# Altium schematic sheet 01-Antennas.SchDoc(01-Antennas)
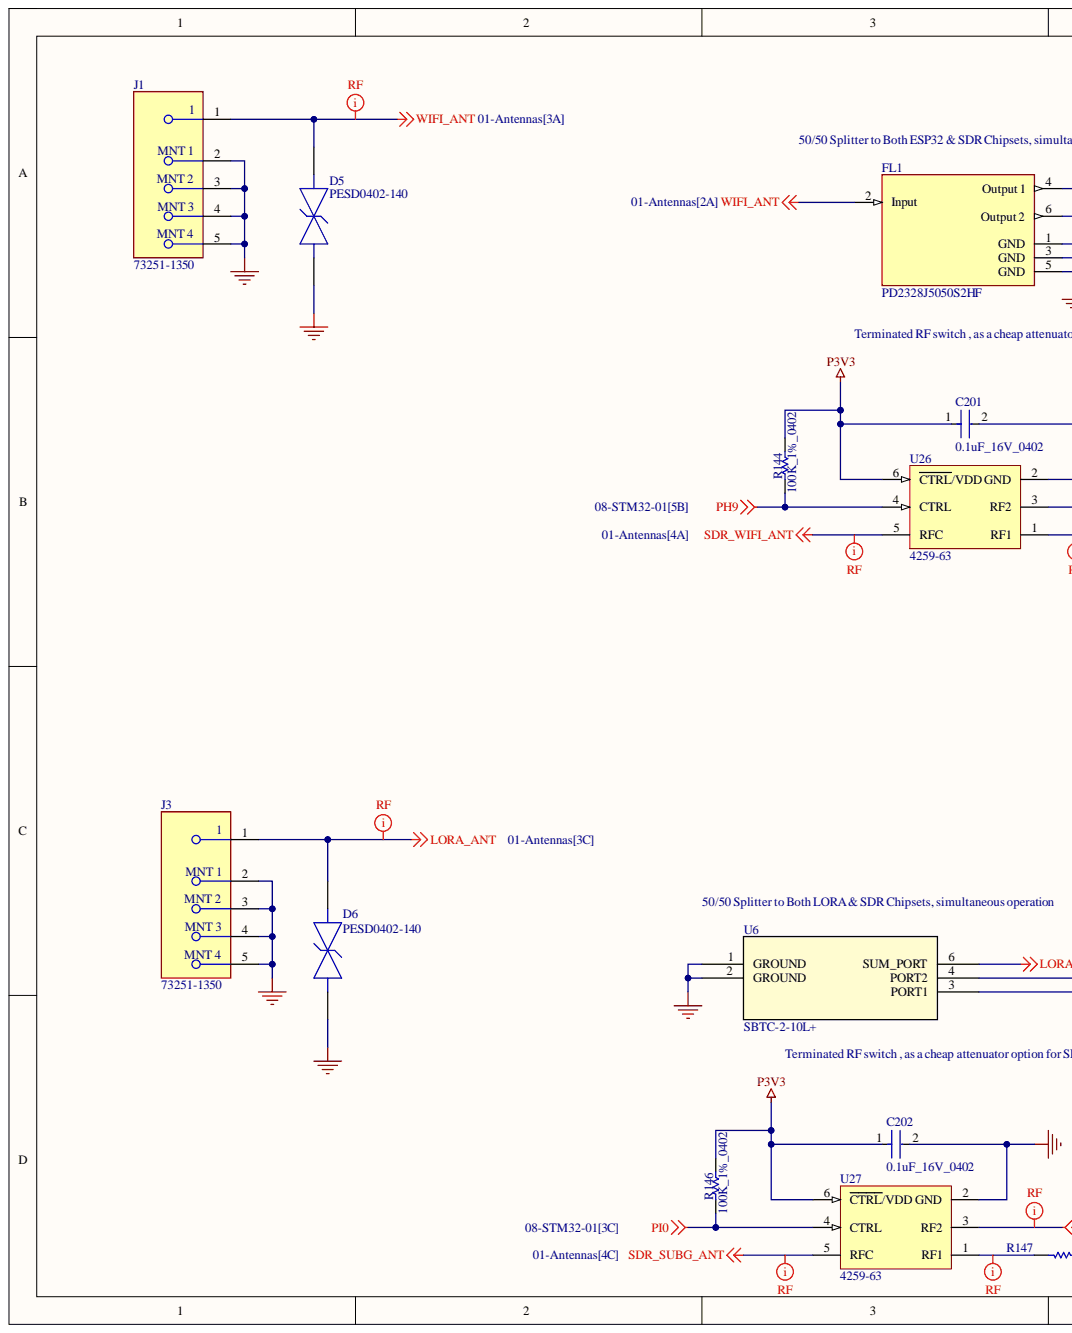
[diagram p0: 01-Antennas.SchDoc, left]
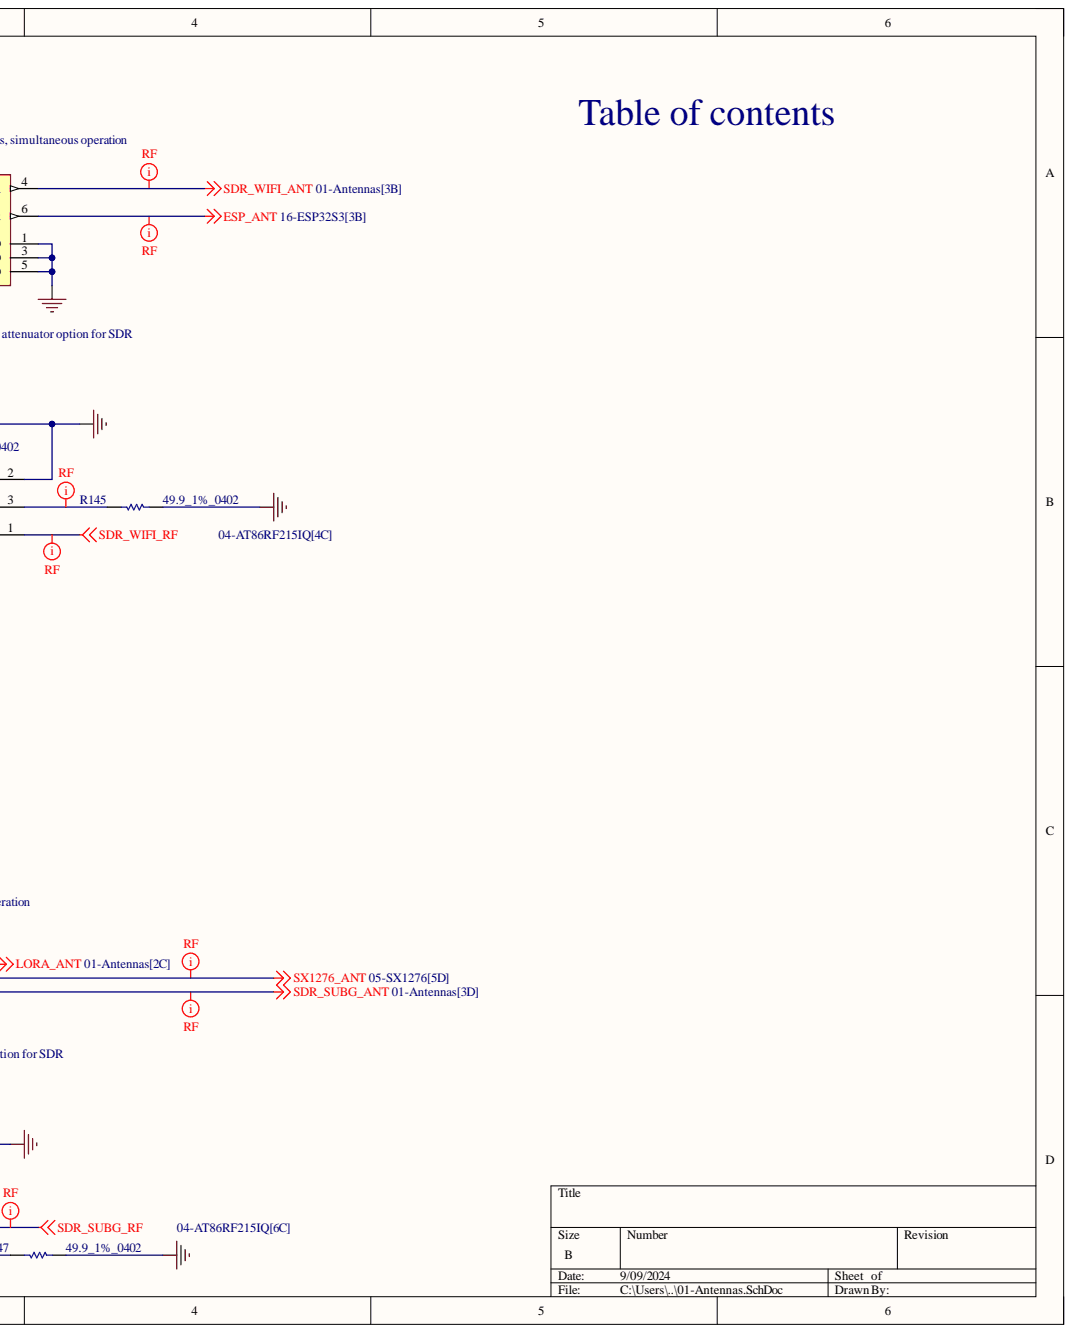
[diagram p1: 01-Antennas.SchDoc, right]

## Parts
C201  0.1uF_16V_0402  [FP-C0402-BB-MFG]
C202  0.1uF_16V_0402  [FP-C0402-BB-MFG]
D5  PESD0402-140  [FP-PESD0402-MFG]
D6  PESD0402-140  [FP-PESD0402-MFG]
FL1  PD2328J5050S2HF  [FP-PD2328J5050S2HF-MFG]
J1  73251-1350  [FP-73251-1350-MFG]
J3  73251-1350  [FP-73251-1350-MFG]
R144  100K_1%_0402  [RESC1005X40X25ML05T10]
R145  49.9_1%_0402  [RESC1005X40X25NL10T10]
R146  100K_1%_0402  [RESC1005X40X25ML05T10]
R147  49.9_1%_0402  [RESC1005X40X25NL10T10]
U6  SBTC-2-10L+  [AT1029_MNC]
U26  4259-63  [PERE-SC-70-6_M]
U27  4259-63  [PERE-SC-70-6_M]

## Nets
ESP_ANT: FL1.6(Output_2)
GND: C201.2 C202.2 D5.1 D6.1 FL1.1(GND) FL1.3(GND) FL1.5(GND) J1.2 J1.3 J1.4 J1.5 J3.2 J3.3 J3.4 J3.5 R145.2 R147.2 U6.1(GROUND) U6.2(GROUND) U26.2(GND) U27.2(GND)
LORA_ANT: D6.2 J3.1 U6.6(SUM_PORT)
P3V3: C201.1 C202.1 R144.2 R146.2 U26.6(CTRL/VDD) U27.6(CTRL/VDD)
PH9: R144.1 U26.4(CTRL)
PI0: R146.1 U27.4(CTRL)
SDR_SUBG_ANT: U6.3(PORT1) U27.5(RFC)
SDR_SUBG_RF: U27.3(RF2)
SDR_WIFI_ANT: FL1.4(Output_1) U26.5(RFC)
SDR_WIFI_RF: U26.1(RF1)
SX1276_ANT: U6.4(PORT2)
WIFI_ANT: D5.2 FL1.2(Input) J1.1
NetR145_1: R145.1 U26.3(RF2)
NetR147_1: R147.1 U27.1(RF1)

## Library data of the parts
C201 C202 = CMP-1034-02068-3: CAP CER 0.1UF 16V 5% X7R 0402; Manufacturer: Kemet; Manufacturer Part Number: C0402C104J4RACTU; Capacitance: 0.10 μF; Material: Ceramic; Temperature Coefficient: 15%; Tolerance: 0.05; Value: 0.10 μF; Automotive: No; Category: Cap; Component Kind: Standard; ComponentLink2Description: Datasheet; ComponentLink2URL: https://content.kemet.com/datasheets/KEM_C1002_X7R_SMD.pdf; Device Class L1: Passive Components; Device Class L2: Capacitors; Device Class L3: Ceramic Capacitors; Digikey Description: CAP CER 0.1UF 16V 5% X7R 0402; Height: 0.55mm; Ipc Land Pattern Name: CAPC10050X50; Lead Free: Yes; Library Name: Altium Content Vault; Mouser Description: CAP CER 0.1UF 16V X7R 0402; Octopart: https://octopart.com/c0402c104j4ractu-kemet-12573893; Package: C0402-BB; RoHS: TRUE; Temperature: -55°C to +125°C; Temperature Characteristic: X7R; Temperature Range High: +125°C; Temperature Range Low: -55°C; Voltage Rating: 16 V
D5 D6 = CMP-08607-000011-1: TVS DIODE 14V 40V 0402; Manufacturer: Littelfuse Inc.; Automotive Grade: Grade 1; Capacitance: 0.25pF; Direction: Bidirectional; Number Of Channels: 1; Value: 0.25pF; Automotive: Yes; Breakdown Voltage: 14V; Category: Diode; Clamping Voltage: 40V; Component Kind: Standard; ComponentLink2Description: Datasheet; ComponentLink2URL: https://www.littelfuse.com/~/media/electronics/datasheets/polymer_esd_suppressors/littelfuse_polymer_esd_suppressor_pesd_catalog_datasheet.pdf.pdf; Device Class L1: Circuit Protection; Device Class L2: TVS Diodes; Device Class L3: unset; Digikey Description: TVS DIODE 14V 40V 0402; Height: 0.43mm; Ipc Land Pattern Name: DIOC10050X33; Lead Free: Yes; Library Name: Altium Content Vault; Manufacturer Part Number: PESD0402-140; Octopart: https://octopart.com/pesd0402-140-littelfuse-74215061; Package: PESD0402; Reverse Standoff Voltage: 14V; RoHS: TRUE; Temperature: -55°C to +125°C; Temperature Range High: +125°C; Temperature Range Low: -55°C
FL1 = CMP-189356-000004-1: RF POWER DVDR 2.3GHZ-2.8GHZ 0805; Frequency: 2.8GHz; Impedance: 50R; Insertion Loss: 0.3dB; Case Code (Imperial): 0805; Case Code (Metric): 2012; Case/Package: 0805; Component Kind: Standard; Length: 0.079inch; Library Name: Altium Content Vault; Max Operating Temperature: 85°C; Min Operating Temperature: -55°C; Mount: Surface Mount; Number of Pins: 6; Package Quantity: 1; Packaging: Tape and Reel; Radiation Hardening: No; Termination: SMD/SMT; Width: 0.05inch
J1 J3 = CMP-04764-000006-1: CONN SMA JACK STR 50 OHM SMD; Manufacturer: Molex; Conn Orientation: Straight; Frequency: 18GHz; Impedance: 50Ω; Is Female: yes; Number Of Contacts: 1; Shielding: true; Standoff Height: 0.41mm; Voltage Rating: 500V; Automotive: No; Category: Conn; Component Kind: Standard; ComponentLink2Description: Datasheet; ComponentLink2URL: https://www.molex.com/pdm_docs/sd/732511350_sd.pdf; Contact Material: Gold; Device Class L1: Connectors; Device Class L2: RF / Coaxial Connectors; Device Class L3: unset; Digikey Description: CONN SMA JACK STR 50 OHM SMD; Footprint Url: https://forum.upverter.com/uploads/default/original/3X/d/a/daeafa2ce4908d4fc4e49f0b17d7d2c986fce444.pdf; Height: 9.655mm; Lead Free: Yes; Library Name: Altium Content Vault; Manufacturer Part Number: 73251-1350; Mouser Description: RF Connectors / Coaxial Connectors SMA ST PCB-JCK STD SMT; Octopart: https://octopart.com/73251-1350-molex-5414390; Package: 73251-1350; RoHS: TRUE; Temperature: -65°C to +165°C; Temperature Range High: +165°C; Temperature Range Low: -65°C
R144 R146 = CMP-2002-07852-1: Manufacturer: Yageo; Part Number: RC0402FR-13100KL; Component Kind: Standard; Library Name: Altium Content Vault
R145 R147 = CMP-2002-04448-1: Manufacturer: KOA Speer; Part Number: RK73H1ETTP49R9F; Component Kind: Standard; Library Name: Altium Content Vault
U6 = SBTC-2-10L+: No Description Available; Mfr_Name: Mini Circuits; Component Kind: Standard; Library Name: SBTC-2-10L&plus_.SchLib; Manufacturer_Part_Number: SBTC-2-10L+; RefDes: RefDes; Type: DEV; Copyright: Copyright (C) 2023 Ultra Librarian. All rights reserved.
U26 U27 = CMP-2000-05298-1: IC RF Switch SPDT, 50 Ohm, 6-Pin SC70, RoHS, Tape and Reel; Manufacturer: Peregrine Semiconductor; Frequency - Lower: 10MHz; Frequency - Upper: 3GHz; IIP3: 55dBm(typ); Impedance: 50Ohm; Insertion Loss @ Frequency: 0.5dB@2GHz; Isolation @ Frequency: 20dB@2GHz(typ); Online Catalog: PE4259; Other Names: 1046-1011-24259-63-ND425963PE4259PE4259SCBC-ZPE4259SCBC-Z-ND; P1dB: 29dBm(typ)IP1dB; RF Type: GeneralPurpose; Topology: Reflective; Voltage - Supply: 1.8V~3.3V; Category: RF/IFandRFID; Circuit: SPDT; Component Kind: Standard; Datasheet URL: https://datasheet.ciiva.com/15100/getdatasheetpartid-685660-15100501.pdf; Datasheet Version: Jan-11; Family: RFSwitches; Features: Single/DualLineControl; Library Name: Altium Content Vault; Manufacturer URL: http://www.psemi.com/; Operating Temperature: -40degC~85degC; Package / Case: 6-TSSOP,SC-88,SOT-363; Package Description: 6-Pin Small Outline Transistor, Body 2.05 x 1.25 mm, Pitch 0.65 mm; Package Reference: SC-70-6; Packaging: Tape&Reel(TR); Series: UltraCMOS; Standard Package: 3,000; Supplier Device Package: SC-70-6
